# Altium schematic sheet AudioWayDSP-MCU.SchDoc
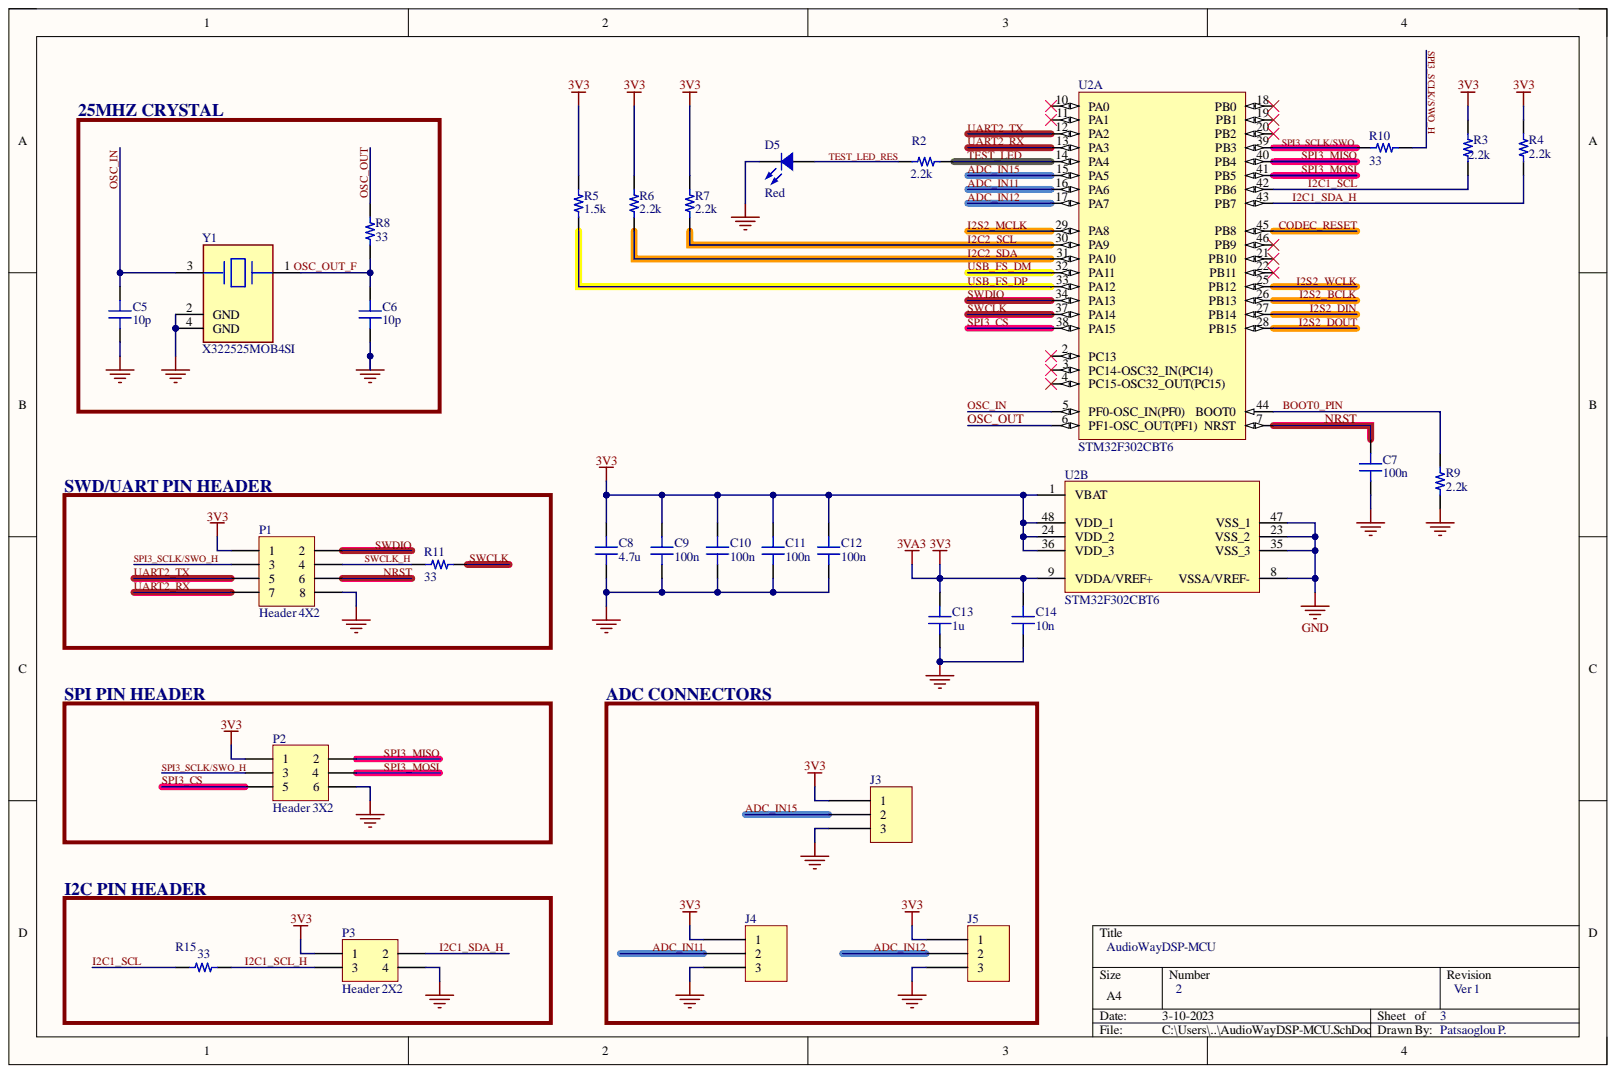
[diagram p0: AudioWayDSP-MCU.SchDoc]

## Parts
C5  10p  [CAP 0402_1005]
C6  10p  [CAP 0402_1005]
C7  100n  [CAP 0402_1005]
C8  4.7u  [CAP 0603_1608]
C9  100n  [CAP 0402_1005]
C10  100n  [CAP 0402_1005]
C11  100n  [CAP 0402_1005]
C12  100n  [CAP 0402_1005]
C13  1u  [CAP 0603_1608]
C14  10n  [CAP 0402_1005]
D5  Red  [LED 0603_1608 RED]
J3  B3B-XH-A(LF)(SN)  [JST XH B3B-XH-A]  (value not drawn)
J4  B3B-XH-A(LF)(SN)  [JST XH B3B-XH-A]  (value not drawn)
J5  B3B-XH-A(LF)(SN)  [JST XH B3B-XH-A]  (value not drawn)
P1  Header 4X2  [HDR2X4]
P2  Header 3X2  [HDR2X3]
P3  Header 2X2  [HDR2X2]
R2  2.2k  [RES 0402_1005]
R3  2.2k  [RES 0402_1005]
R4  2.2k  [RES 0402_1005]
R5  1.5k  [RES 0402_1005]
R6  2.2k  [RES 0402_1005]
R7  2.2k  [RES 0402_1005]
R8  33  [RES 0402_1005]
R9  2.2k  [RES 0402_1005]
R10  33  [RES 0402_1005]
R11  33  [RES 0402_1005]
R15  33  [RES 0402_1005]
U2  STM32F302CBT6  [STM-LQFP48_N]  (parts A,B)
Y1  X322525MOB4SI  [FP-ABM8-MFG]

## Nets
3V3: C8.2 C9.2 C10.2 C11.2 C12.2 C13.2 C14.2 J3.1 J4.1 J5.1 P1.1 P2.1 P3.1 R3.2 R4.2 R5.2 R6.2 R7.2 U2.1(VBAT) U2.9(VDDA/VREF+) U2.24(VDD_2) U2.36(VDD_3) U2.48(VDD_1)
GND: C5.1 C6.1 C7.1 C8.1 C9.1 C10.1 C11.1 C12.1 C13.1 C14.1 D5.2 J3.3 J4.3 J5.3 P1.8 P2.6 P3.4 R9.1 U2.8(VSSA/VREF-) U2.23(VSS_2) U2.35(VSS_3) U2.47(VSS_1) Y1.2(GND) Y1.4(GND)
ADC_IN11: J4.2 U2.16(PA6)
ADC_IN12: J5.2 U2.17(PA7)
ADC_IN15: J3.2 U2.15(PA5)
BOOT0_PIN: R9.2 U2.44(BOOT0)
CODEC_RESET: U2.45(PB8)
I2C1_SCL: R3.1 R15.1 U2.42(PB6)
I2C1_SCL_H: P3.3 R15.2
I2C1_SDA_H: P3.2 R4.1 U2.43(PB7)
I2C2_SCL: R7.1 U2.30(PA9)
I2C2_SDA: R6.1 U2.31(PA10)
I2S2_BCLK: U2.26(PB13)
I2S2_DIN: U2.27(PB14)
I2S2_DOUT: U2.28(PB15)
I2S2_MCLK: U2.29(PA8)
I2S2_WCLK: U2.25(PB12)
NRST: C7.2 P1.6 U2.7(NRST)
OSC_IN: C5.2 U2.5(PF0-OSC_IN(PF0)) Y1.3
OSC_OUT: R8.2 U2.6(PF1-OSC_OUT(PF1))
OSC_OUT_F: C6.2 R8.1 Y1.1
SPI3_CS: P2.5 U2.38(PA15)
SPI3_MISO: P2.2 U2.40(PB4)
SPI3_MOSI: P2.4 U2.41(PB5)
SPI3_SCLK/SWO: R10.2 U2.39(PB3)
SPI3_SCLK/SWO_H: P1.3 P2.3 R10.1
SWCLK: R11.1 U2.37(PA14)
SWCLK_H: P1.4 R11.2
SWDIO: P1.2 U2.34(PA13)
TEST_LED: R2.1 U2.14(PA4)
TEST_LED_RES: D5.1 R2.2
UART2_RX: P1.7 U2.13(PA3)
UART2_TX: P1.5 U2.12(PA2)
USB_FS_DM: U2.32(PA11)
USB_FS_DP: R5.1 U2.33(PA12)

Not connected: U2 2(PC13) 3(PC14-OSC32_IN(PC14)) 4(PC15-OSC32_OUT(PC15)) 10(PA0) 11(PA1) 18(PB0) 19(PB1) 20(PB2) 21(PB10) 22(PB11) 46(PB9)

## Library data of the parts
C5 C6 = 04025U100JAT2A: CAP CER 10PF 50V C0G/NP0 0402; manufacturer: AVX Corporation; Database Table Name: Capacitors - Ceramic - 0402; Temperature Coefficient: C0G, NP0; Tolerance: ±5%; Applications: RF, Microwave, High Frequency; Capacitance: 10pF; Component Kind: Standard; componentlink1description: Datasheet; componentlink1url: http://datasheets.avx.com/U-Series.pdf; componentlink2description: DigiKey Link; componentlink2url: http://digikey.com/product-detail/en/avx-corporation/04025U100JAT2A/478-3831-1-ND/1118493; Device Package: 0402 (1005 Metric); Features: Ultra Low ESR; lastupdated: 08-Feb-22 12:26:58 PM; Library Name: Celestial Altium Library - altium_library.DbLib; Lifecycle Status: Active; Minimum Order: 1; Mounting Type: Surface Mount, MLCC; Operating Temperature: -55°C ~ 125°C; Package / Case: 0402 (1005 Metric); packaging: TapeAndReel; Part Number: 04025U100JAT2A; Part Status: Active; partid: 1802; price: 0.02; series: U; Size / Dimension: 0.039" L x 0.020" W (1.00mm x 0.50mm); Supplier 1: DigiKey; Supplier Part Number 1: 478-3831-1-ND; Thickness (Max): 0.024" (0.60mm); Value: 10p; Voltage - Rated: 50V
C7 C9-C12 = CGA2B3X5R1H104M050BB: CAP CER 0.1UF 50V X5R 0402; manufacturer: TDK Corporation; Capacitance: 0.1 µF; Database Table Name: Capacitors - Ceramic - 0402; Tolerance: ±20%; Applications: Automotive; Component Kind: Standard; componentlink1description: Datasheet; componentlink1url: https://product.tdk.com/info/en/catalog/datasheets/mlcc_automotive_general_en.pdf?ref_disty=digikey; componentlink2description: DigiKey Link; componentlink2url: https://www.digikey.com/en/products/detail/tdk-corporation/CGA2B3X5R1H104M050BB/3949450; Device Package: 0402 (1005 Metric); lastupdated: 01-Mar-23 8:04:14 PM; Library Name: Celestial Altium Library - altium_library.DbLib; Lifecycle Status: Active; Minimum Order: 1; Mounting Type: Surface Mount, MLCC; Operating Temperature: -55°C ~ 85°C; Package / Case: 0402 (1005 Metric); packaging: TapeAndReel; Part Number: CGA2B3X5R1H104M050BB; Part Status: Active; partid: 1792; price: 0.01; Ratings: AEC-Q200; series: CGA; Size / Dimension: 0.039" L x 0.020" W (1.00mm x 0.50mm); Supplier 1: DigiKey; Supplier Part Number 1: 445-12214-1-ND; Temperature Coefficient: X5R; Thickness (Max): 0.022" (0.55mm); Value: 100n; Voltage - Rated: 50V
C8 = GRM188R61C475KE11D: CAP CER 4.7UF 16V X5R 0603; manufacturer: Murata Electronics; Capacitance: 4.7 µF; Database Table Name: Capacitors - Ceramic - 0603; Tolerance: ±10%; Applications: General Purpose; Component Kind: Standard; componentlink1description: Datasheet; componentlink1url: https://search.murata.co.jp/Ceramy/image/img/A01X/G101/ENG/GRM188R61C475KE11-01.pdf; componentlink2description: DigiKey Link; componentlink2url: https://www.digikey.com/en/products/detail/murata-electronics/GRM188R61C475KE11D/4905341; Device Package: 0603 (1608 Metric); lastupdated: 01-Mar-23 8:07:51 PM; Library Name: Celestial Altium Library - altium_library.DbLib; Lifecycle Status: Active; Minimum Order: 1; Mounting Type: Surface Mount, MLCC; Operating Temperature: -55°C ~ 85°C; Package / Case: 0603 (1608 Metric); packaging: TapeAndReel; Part Number: GRM188R61C475KE11D; Part Status: Active; partid: 2388; price: 0.03; series: GRM; Size / Dimension: 0.063" L x 0.031" W (1.60mm x 0.80mm); Supplier 1: DigiKey; Supplier Part Number 1: 490-10730-1-ND; Temperature Coefficient: X5R; Thickness (Max): 0.037" (0.95mm); Value: 4.7u; Voltage - Rated: 16V
C13 = 06035D105KAT2A: CAP CER 1UF 50V X5R 0603; manufacturer: AVX Corporation; Capacitance: 1µF; Database Table Name: Capacitors - Ceramic - 0603; Tolerance: ±10%; Applications: General Purpose; Component Kind: Standard; componentlink1description: Datasheet; componentlink1url: http://datasheets.avx.com/cx5r.pdf; componentlink2description: DigiKey Link; componentlink2url: http://digikey.com/product-detail/en/avx-corporation/06035D105KAT2A/478-10067-1-ND/6564289; Device Package: 0603 (1608 Metric); lastupdated: 02-Sep-20 1:03:26 AM; Library Name: Celestial Altium Library - altium_library.DbLib; Lifecycle Status: Active; Minimum Order: 1; Mounting Type: Surface Mount, MLCC; Operating Temperature: -55°C ~ 85°C; Package / Case: 0603 (1608 Metric); packaging: TapeAndReel; Part Number: 06035D105KAT2A; Part Status: Active; partid: 7730; price: 0.15; series: -; Size / Dimension: 0.063" L x 0.032" W (1.60mm x 0.81mm); Supplier 1: DigiKey; Supplier Part Number 1: 478-10067-1-ND; Temperature Coefficient: X5R; Thickness (Max): 0.035" (0.90mm); Value: 1u; Voltage - Rated: 50V
C14 = C0402C103K5RECAUTO: CAP CER 0402 10NF 50V X7R 10%; manufacturer: KEMET; Capacitance: 10000 pF; Database Table Name: Capacitors - Ceramic - 0402; Applications: Automotive, ESD Protection; Component Kind: Standard; componentlink1description: Datasheet; componentlink1url: https://api.kemet.com/component-edge/download/datasheet/C0402C103K5RECAUTO.pdf; componentlink2description: DigiKey Link; componentlink2url: https://www.digikey.com/en/products/detail/kemet/C0402C103K5RECAUTO/8646477; Device Package: 0402 (1005 Metric); lastupdated: 01-Mar-23 8:03:25 PM; Library Name: Celestial Altium Library - altium_library.DbLib; Lifecycle Status: Active; Minimum Order: 1; Mounting Type: Surface Mount, MLCC; Operating Temperature: -55°C ~ 125°C; Package / Case: 0402 (1005 Metric); packaging: TapeAndReel; Part Number: C0402C103K5RECAUTO; Part Status: Active; partid: 38916; price: 0.01; Ratings: AEC-Q200; series: ESD SMD Auto X7R; Size / Dimension: 0.039" L x 0.020" W (1.00mm x 0.50mm); Supplier 1: DigiKey; Supplier Part Number 1: 399-C0402C103K5RECAUTOCT-ND; Temperature Coefficient: X7R; Thickness (Max): 0.022" (0.55mm); Tolerance: ±10%; Value: 10n; Voltage - Rated: 50V
D5 = 5973005507F: LED RED 0603 SMD; Manufacturer: Dialight; Configuration: Standard; Current - Test: 20mA; Database Table Name: LED - Indication; Lens Color: 0.68mm; Lens Size: 1.20mm x 0.80mm; Lens Style: Rectangle with Flat Top; Millicandela Rating: 90mcd; Voltage - Forward (Vf) (Typ): 2V; Wavelength - Dominant: 630nm; Color: Red; Component Kind: Standard; ComponentLink1Description: Datasheet; ComponentLink1URL: http://www.dialightsignalsandcomponents.com/Assets/Drawings/2D_Drawings_DrawingDetailedSpec/NewDrawings/18369A.pdf; ComponentLink2Description: DigiKey Link; ComponentLink2URL: https://www.digikey.com/en/products/detail/dialight/5973005507F/9385429; Device Package: 0603 (1608 Metric); Height (Max): 0.68mm; LastUpdated: 01-Mar-23 8:56:29 PM; Library Name: Celestial Altium Library - altium_library.DbLib; Lifecycle Status: Active; Minimum Order: 1; Mounting Type: Surface Mount; Package / Case: 0603 (1608 Metric); Packaging: TapeAndReel; Part Number: 5973005507F; Part Status: Active; PartId: 378; Price: 0.08; Series: 597; Size / Dimension: 1.60mm L x 0.80mm W; Supplier 1: DigiKey; Supplier Device Package: 0603; Supplier Part Number 1: 350-4374-1-ND; Value: Red
J3-J5 = B3B-XH-A(LF)(SN): CONN HEADER VERT 3POS 2.5MM; Manufacturer: JST Sales America Inc.; Contact Length - Post: 0.134" (3.40mm); Current Rating (Amps): 3A; Database Table Name: Connectors - Rectangular Headers Male Pins; Material Flammability Rating: UL94 V-0; Number of Positions: 3; Number of Positions Loaded: All; Pitch - Mating: 0.098" (2.50mm); Shrouding: Shrouded - 4 Wall; Style: Board to Cable/Wire; Voltage Rating: 250V; Component Kind: Standard; ComponentLink1Description: Datasheet; ComponentLink1URL: http://www.jst-mfg.com/product/pdf/eng/eXH.pdf; ComponentLink2Description: DigiKey Link; ComponentLink2URL: http://digikey.com/product-detail/en/jst-sales-america-inc/B3B-XH-A-LF-SN/455-2248-ND/1651046; Connector Type: Header; Contact Finish - Mating: Tin; Contact Finish - Post: Tin; Contact Material: Brass; Contact Shape: Square; Contact Type: Male Pin; Fastening Type: Detent Lock; Insulation Color: Natural; Insulation Height: 0.276" (7.00mm); Insulation Material: Polyamide (PA66), Nylon 6/6; LastUpdated: 12-Jan-20 11:46:22 PM; Library Name: Celestial Altium Library - altium_library.DbLib; Lifecycle Status: Active; Minimum Order: 1; Mounting Type: Through Hole; Number of Rows: 1; Operating Temperature: -25°C ~ 85°C; Packaging: Bulk; Part Number: B3B-XH-A(LF)(SN); Part Status: Active; PartId: 68; Price: 0.19; Series: XH; Supplier 1: DigiKey; Supplier Part Number 1: 455-2248-ND; Termination: Press-Fit, Solder
P1 = Header 4X2: Header, 4-Pin, Dual row; Component Kind: Standard; LatestRevisionDate: 17-Jul-2002; LatestRevisionNote: Re-released for DXP Platform.; Library Name: Miscellaneous Connectors.IntLib; Signal Integrity: Connector; Publisher: Altium Limited
P2 = Header 3X2: Header, 3-Pin, Dual row; Component Kind: Standard; LatestRevisionDate: 17-Jul-2002; LatestRevisionNote: Re-released for DXP Platform.; Library Name: Miscellaneous Connectors.IntLib; Signal Integrity: Connector; Publisher: Altium Limited
P3 = Header 2X2: Header, 2-Pin, Dual row; Component Kind: Standard; LatestRevisionDate: 17-Jul-2002; LatestRevisionNote: Re-released for DXP Platform.; Library Name: Miscellaneous Connectors.IntLib; Signal Integrity: Connector; Publisher: Altium Limited
R2-R4 R6 R7 R9 = ERA-2VRW2201X: RES 2.2K OHM 0.05% 1/10W 0402; Manufacturer: Panasonic Electronic Components; Database Table Name: Resistors - Surface Mount - 0402; Power (Watts): 0.1W, 1/10W; Resistance: 2.2 kOhms; Temperature Coefficient: ±10ppm/°C; Component Kind: Standard; ComponentLink1Description: Datasheet; ComponentLink1URL: https://b2b-api.panasonic.eu/file_stream/pids/fileversion/9152; ComponentLink2Description: DigiKey Link; ComponentLink2URL: https://www.digikey.com/en/products/detail/panasonic-electronic-components/ERA-2VRW2201X/12087785; Composition: Thin Film; Device Package: 0402 (1005 Metric); Features: Anti-Sulfur, Automotive AEC-Q200; Height - Seated (Max): 0.016" (0.40mm); LastUpdated: 01-Mar-23 8:20:02 PM; Library Name: Celestial Altium Library - altium_library.DbLib; Lifecycle Status: Active; Minimum Order: 1; Number of Terminations: 2; Operating Temperature: -55°C ~ 155°C; Package / Case: 0402 (1005 Metric); Packaging: TapeAndReel; Part Number: ERA-2VRW2201X; Part Status: Active; PartId: 60215; Price: 0.24; Ratings: AEC-Q200; Series: ERA-2V; Size / Dimension: 0.039" L x 0.020" W (1.00mm x 0.50mm); Supplier 1: DigiKey; Supplier Device Package: 0402; Supplier Part Number 1: 10-ERA-2VRW2201XCT-ND; Tolerance: ±0.05%; Value: 2.2k
R5 = ERJ-PA2F1501X: RES 1.5K OHM 1% 1/4W 0402; Manufacturer: Panasonic Electronic Components; Database Table Name: Resistors - Surface Mount - 0402; Power (Watts): 0.25W, 1/4W; Resistance: 1.5 kOhms; Temperature Coefficient: ±100ppm/°C; Component Kind: Standard; ComponentLink1Description: Datasheet; ComponentLink1URL: https://industrial.panasonic.com/cdbs/www-data/pdf/RDO0000/AOA0000C331.pdf; ComponentLink2Description: DigiKey Link; ComponentLink2URL: https://www.digikey.com/en/products/detail/panasonic-electronic-components/ERJ-PA2F1501X/5402651; Composition: Thick Film; Device Package: 0402 (1005 Metric); Features: Automotive AEC-Q200, Pulse Withstanding; Height - Seated (Max): 0.016" (0.40mm); LastUpdated: 01-Mar-23 8:21:08 PM; Library Name: Celestial Altium Library - altium_library.DbLib; Lifecycle Status: Active; Minimum Order: 1; Number of Terminations: 2; Operating Temperature: -55°C ~ 155°C; Package / Case: 0402 (1005 Metric); Packaging: TapeAndReel; Part Number: ERJ-PA2F1501X; Part Status: Active; PartId: 7855; Price: 0.02; Ratings: AEC-Q200; Series: ERJ-PA2; Size / Dimension: 0.039" L x 0.020" W (1.00mm x 0.50mm); Supplier 1: DigiKey; Supplier Device Package: 402; Supplier Part Number 1: P17225CT-ND; Tolerance: ±1%; Value: 1.5k
R8 R10 R11 R15 = CRCW040233R0FKEDC: RES 33 OHM 1% 1/16W 0402; Manufacturer: Vishay Dale; Database Table Name: Resistors - Surface Mount - 0402; Power (Watts): 0.063W, 1/16W; Resistance: 33 Ohms; Temperature Coefficient: ±100ppm/°C; Component Kind: Standard; ComponentLink1Description: Datasheet; ComponentLink1URL: http://www.vishay.com/docs/28773/crcwce3.pdf; ComponentLink2Description: DigiKey Link; ComponentLink2URL: https://www.digikey.com/en/products/detail/vishay-dale/CRCW040233R0FKEDC/7928390; Composition: Thick Film; Device Package: 0402 (1005 Metric); Height - Seated (Max): 0.014" (0.35mm); LastUpdated: 01-Mar-23 8:20:49 PM; Library Name: Celestial Altium Library - altium_library.DbLib; Lifecycle Status: Active; Minimum Order: 1; Number of Terminations: 2; Operating Temperature: -55°C ~ 155°C; Package / Case: 0402 (1005 Metric); Packaging: CutTape; Part Number: CRCW040233R0FKEDC; Part Status: Active; PartId: 12692; Price: 0.01; Series: CRCW-C; Size / Dimension: 0.039" L x 0.020" W (1.00mm x 0.50mm); Supplier 1: DigiKey; Supplier Device Package: 0402; Supplier Part Number 1: 541-3968-1-ND; Tolerance: ±1%; Value: 33
U2 = CMP-0572-00016-2: ARM Cortex-M4 32-bit MCU, 128 KB Flash, 24 KB Internal RAM, 37 I/Os, 48-pin LQFP, -40 to 85 degC, Tray; D/A Converter: 1x12-bit (1 Channel); FLASH Size (Prog) (kB): 128; I/Os (High Current): 37; Internal RAM Size (kB): 24; Operating Frequency(F) (Processor speed) (MHz): 72; Supply Voltage(Vcc) min (V): 2; Component Kind: Standard; ComponentLink1Description: Manufacturer URL; ComponentLink1URL: http://www.st.com/web/en/home.html; ComponentLink2Description: Datasheet; ComponentLink2URL: http://www.st.com/st-web-ui/static/active/en/resource/technical/document/datasheet/DM00058181.pdf; ComponentLink3Description: Package Specification; ComponentLink3URL: http://www.st.com/st-web-ui/static/active/en/resource/technical/document/datasheet/DM00058181.pdf; Core: ARM Cortex-M4; DatasheetVersion: Rev. 6; Library Name: Altium Content Vault; Mounting Technology: Surface Mount; PackageDescription: 48-Pin Low Profile Quad Flat Package, 7 x 7 mm Body, 0.5 mm Pitch; PackageReference: LQFP48; PackageVersion: Rev. 6, 06/2013; Packing: Tray; PartNumber: STM32F302CBT6
Y1 = CMP-27762-000036-1: Crystal 25MHz Yangxing-Tech-X322525MOB4SI; Accuracy: 20ppm; ESR (Equivalent Series Resistance): 50R; Frequency: 24MHz; Frequency Stability: 50ppm; Load Capacitance: 18pF; Operating Frequency: 24 MHz; Case/Package: CSMD; Component Kind: Standard; Depth: 2.5mm; Frequency Tolerance: 20ppm; Height: 0.031inch; Lead Free: Lead Free; Length: 3.2mm; Library Name: Altium Content Vault; Max Operating Temperature: 70°C; Min Operating Temperature: -20°C; Mount: Surface Mount; Number of Pins: 4; Package Quantity: 1000; Packaging: Tape and Reel; Radiation Hardening: No; REACH SVHC: No SVHC; Resistor Type: Ceramic; RoHS Compliant: Yes; Series: ABM8; Series Resistance: 50R; Termination: SMD/SMT; Tolerance: 20ppm; Width: 2.5mm
